# Altium schematic sheet [21]-AXEL.SchDoc([21]-AXEL)
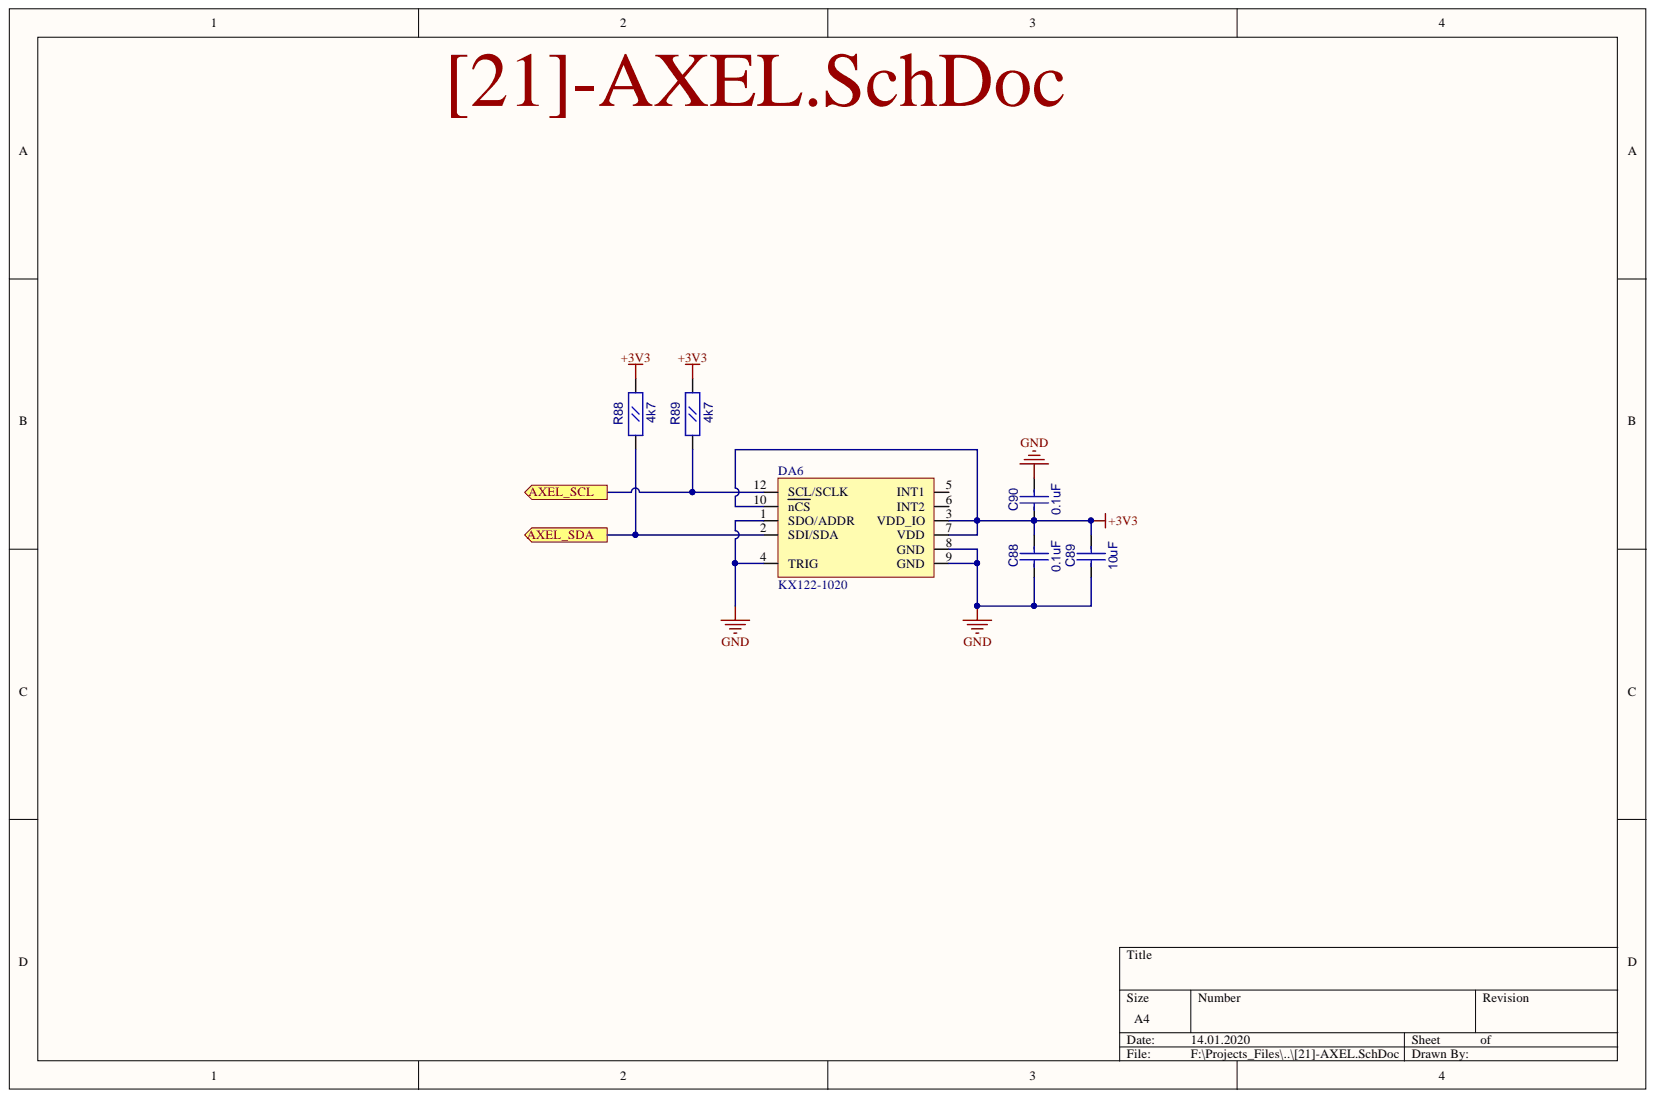
[diagram p0: [21]-AXEL.SchDoc]

## Parts
C88  0.1uF  [CC0603]
C89  10uF  [CC0805]
C90  0.1uF  [CC0603]
DA6  KX122-1020  [LGA-12]
R88  4k7  [RC0603]
R89  4k7  [RC0603]

## Ports
AXEL_SCL, AXEL_SDA

## Nets
+3V3: C88.2 C89.2 C90.1 DA6.3(VDD_IO) DA6.7(VDD) DA6.10(nCS) R88.2 R89.2
GND: C88.1 C89.1 C90.2 DA6.1(SDO/ADDR) DA6.4(TRIG) DA6.8(GND) DA6.9(GND)
AXEL_SCL: DA6.12(SCL/SCLK) R89.1 ; port AXEL_SCL
AXEL_SDA: DA6.2(SDI/SDA) R88.1 ; port AXEL_SDA

Not connected: DA6 5(INT1) 6(INT2)

## Library data of the parts
C88 C90 = CC0603: Value: 0.1uF; Description: CC0603; Component Kind: Standard; Library Name: fizik_lib.SchLib
C89 = CC0805: Value: 10uF; Description: CC0805; Component Kind: Standard; Library Name: fizik_lib.SchLib
DA6 = KX022-1020: Value: KX122-1020; Component Kind: Standard; Library Name: fizik_lib.SchLib
R88 R89 = RC0603: Value: 4k7; Description: RC0603; Component Kind: Standard; Library Name: fizik_lib.SchLib
